# Altium schematic sheet INA240_Breakout.SchDoc
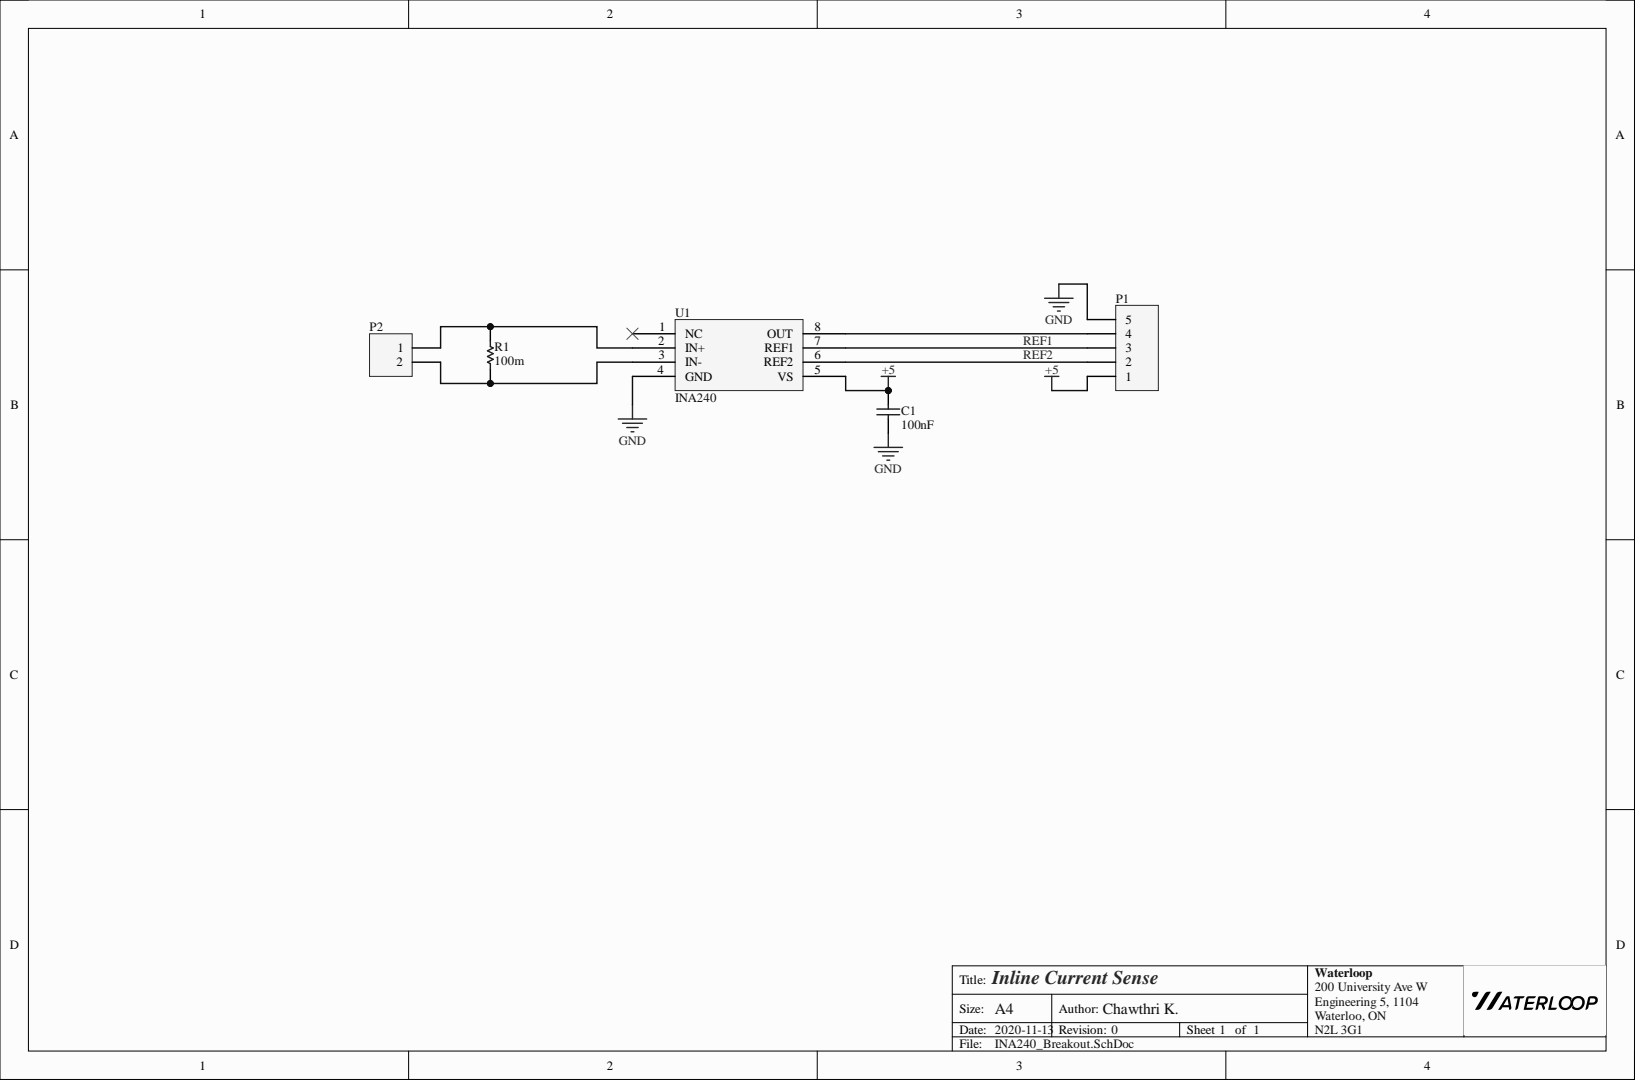
[diagram p0: INA240_Breakout.SchDoc]

## Parts
C1  value 100nF  [0805 - Capacitor]  (hidden comment: Capacitor - Nonpolarized)
P1  1x5 Header  [HDR1X5]  (value not drawn)
P2  1x2 Header  [HDR1X2]  (value not drawn)
R1  value 100m  [0805 - Resistor]  (hidden comment: Resistor)
U1  INA240  [INA240]

## Nets
+5: C1.2 P1.1 U1.5(VS)
GND: C1.1 P1.5 U1.4(GND)
REF1: P1.3 U1.7(REF1)
REF2: P1.2 U1.6(REF2)
NetP1_4: P1.4 U1.8(OUT)
NetP2_1: P2.1 R1.1 U1.2(IN+)
NetP2_2: P2.2 R1.2 U1.3(IN-)

Not connected: U1 1(NC)

## Library data of the parts
C1 = Capacitor - Nonpolarized: Nonpolarized Capacitor; Manufacturer: Yageo; Manufacturer Part Number: CC0805KRX7R9BB104; Component Kind: Standard; Library Name: General.SchLib; Signal Integrity: Cap; Simulation: CAP; Supplier Part Number: 311-1140-1-ND
P1 = 1x5 Header: 1x5 Pin Header; Component Kind: Standard; Library Name: GeneralConnectors.IntLib; Signal Integrity: Connector
P2 = 1x2 Header: 1x2 Pin Header; Component Kind: Standard; Library Name: GeneralConnectors.IntLib; Signal Integrity: Connector
R1 = Resistor: Manufacturer: CTS; Manufacturer Part Number: 73L3R10J; Component Kind: Standard; Description: Resistor; Library Name: General.SchLib; Signal Integrity: Res; Simulation: RESISTOR; Supplier Part Number: 73L3R10JCT-ND
U1 = INA240: -4V to 80V Current Sense Amplifier; Manufacturer: Texas Instruments; Manufacturer Part Number: INA240A2DR; Component Kind: Standard; Datasheet: https://www.ti.com/lit/ds/sbos662b/sbos662b.pdf; Digikey Part Number: 296-51173-1-ND; Library Name: GeneralComponents.IntLib
